# Altium schematic sheet CV-UARTPmod.SchDoc
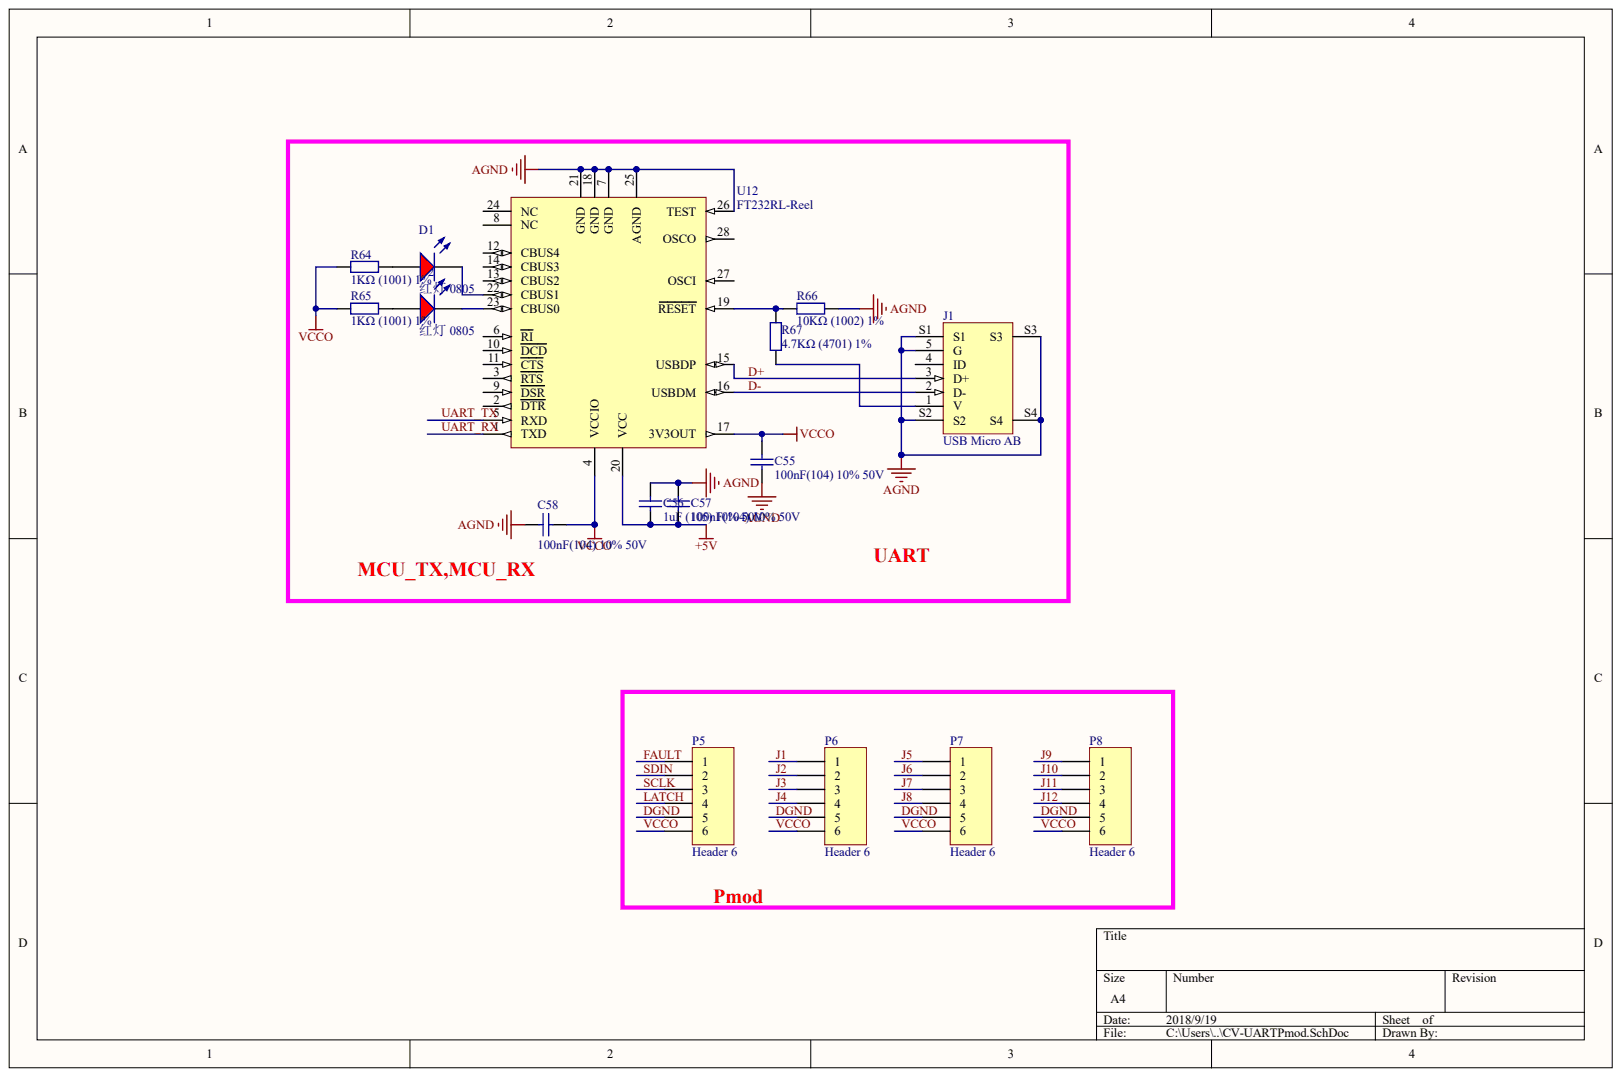
[diagram p0: CV-UARTPmod.SchDoc]

## Parts
C55  100nF(104) 10% 50V
C56  1uF (105) 10% 50V
C57  100nF(104) 10% 50V
C58  100nF(104) 10% 50V
D1  红灯 0805  Package: 0805
D2  红灯 0805  Package: 0805
J1  USB Micro AB
P5  Header 6
P6  Header 6
P7  Header 6
P8  Header 6
R64  1KΩ (1001) ±1%
R65  1KΩ (1001) ±1%
R66  10KΩ (1002) ±1%
R67  4.7KΩ (4701) ±1%
U12  FT232RL-Reel

## Nets
+5V: C56.2 C57.2 U12.20(VCC)
AGND: C55.2 C56.1 C57.1 C58.1 J1.5(G) J1.S1 J1.S2 J1.S3 J1.S4 R66.1 U12.7(GND) U12.18(GND) U12.21(GND) U12.25(AGND) U12.26(TEST)
D+: J1.3(D+) U12.15(USBDP)
D-: J1.2(D-) U12.16(USBDM)
DGND: P5.5 P6.5 P7.5 P8.5
FAULT: P5.1
J1: P6.1
J2: P6.2
J3: P6.3
J4: P6.4
J5: P7.1
J6: P7.2
J7: P7.3
J8: P7.4
J9: P8.1
J10: P8.2
J11: P8.3
J12: P8.4
LATCH: P5.4
SCLK: P5.3
SDIN: P5.2
UART_RX: U12.1(TXD)
UART_TX: U12.5(RXD)
VCCO: C55.1 C58.2 P5.6 P6.6 P7.6 P8.6 R64.2 R65.2 U12.4(VCCIO) U12.17(3V3OUT)
NetD1_A: D1.A R64.1
NetD1_K: D1.K U12.22(CBUS1)
NetD2_A: D2.A R65.1
NetD2_K: D2.K U12.23(CBUS0)
NetJ1_1: J1.1(V) R67.2
NetR66_2: R66.2 R67.1 U12.19(RESET)

Not connected: J1 4(ID); U12 2(DTR) 3(RTS) 6(RI) 8(NC) 9(DSR) 10(DCD) 11(CTS) 12(CBUS4) 13(CBUS2) 14(CBUS3) 24(NC) 27(OSCI) 28(OSCO)

## Library data of the parts
J1 = (no symbol name): ContactMaterial: Copper Alloy; ContactPlating: Gold over Palladium Nickel; PCB_Layout: Complies with manufacturer's recommendation.; DrillSize: Corresponds to nominal value.; PanelGround: Without; Published: 15-Jul-2004; DatasheetDocument: 11-Apr-2003; PackageDocument: 11-Apr-2003; PackageReference: 353576; HousingMaterial: Thermoplastic; ComponentLink1Description: Manufacturer Link; ComponentLink1URL: http://www.tycoelectronics.com/; ComponentLink2Description: Packaging Specification; ComponentLink2URL: http://ecommas.tycoelectronics.com/commerce/DocumentDelivery/DDEController?Action=showdoc&DocId=Customer+Drawing%7F353576%7FD%7Ftif%7FJapanese%7FJPN_CD_353576_D.tif; Publisher: Altium Limited
C55-C58 D1 D2 P5-P8 R64-R67 U12 = (no symbol name)
  C55 C57 C58: ComponentLink1Description: 供应商链接; ComponentLink1URL: http://www.szlcsc.com/product/details_50686.html; Package: 0805; Supplier: LC; SuppliersPartNumber: C49678
  C56: ComponentLink1Description: 供应商链接; ComponentLink1URL: http://www.szlcsc.com/product/details_29074.html; Package: 0805; Supplier: LC; SuppliersPartNumber: C28323
  D1 D2: ComponentLink1Description: 供应商链接; ComponentLink1URL: http://www.szlcsc.com/product/details_2668.html; Supplier: LC; SuppliersPartNumber: C2295
  P5-P8: LatestRevisionDate: 17-Jul-2002; LatestRevisionNote: Re-released for DXP Platform.
  R64 R65: ComponentLink1Description: 供应商链接; ComponentLink1URL: http://www.szlcsc.com/product/details_18201.html; Package: 0805; Supplier: LC; SuppliersPartNumber: C17513
  R66: ComponentLink1Description: 供应商链接; ComponentLink1URL: http://www.szlcsc.com/product/details_18102.html; Package: 0805; Supplier: LC; SuppliersPartNumber: C17414
  R67: ComponentLink1Description: 供应商链接; ComponentLink1URL: http://www.szlcsc.com/product/details_18361.html; Package: 0805; Supplier: LC; SuppliersPartNumber: C17673
  U12: Published: 19-Aug-2010; DatasheetVersion: ver 2.07, Jul-2010; PackageVersion: 2010; PackageReference: SSOP-28; PackageDescription: 28-Pin Small Outline Package 0.65 mm Pitch; ComponentLink1Description: Manufacturer Link; ComponentLink1URL: http://www.ftdichip.com/Products/ICs/FT232R.htm; ComponentLink2Description: Datasheet; ComponentLink2URL: http://www.ftdichip.com/Support/Documents/DataSheets/ICs/DS_FT232R.pdf
  P5-P8 U12: Publisher: Altium Limited
